# Altium schematic sheet eDP.SchDoc(eDP)
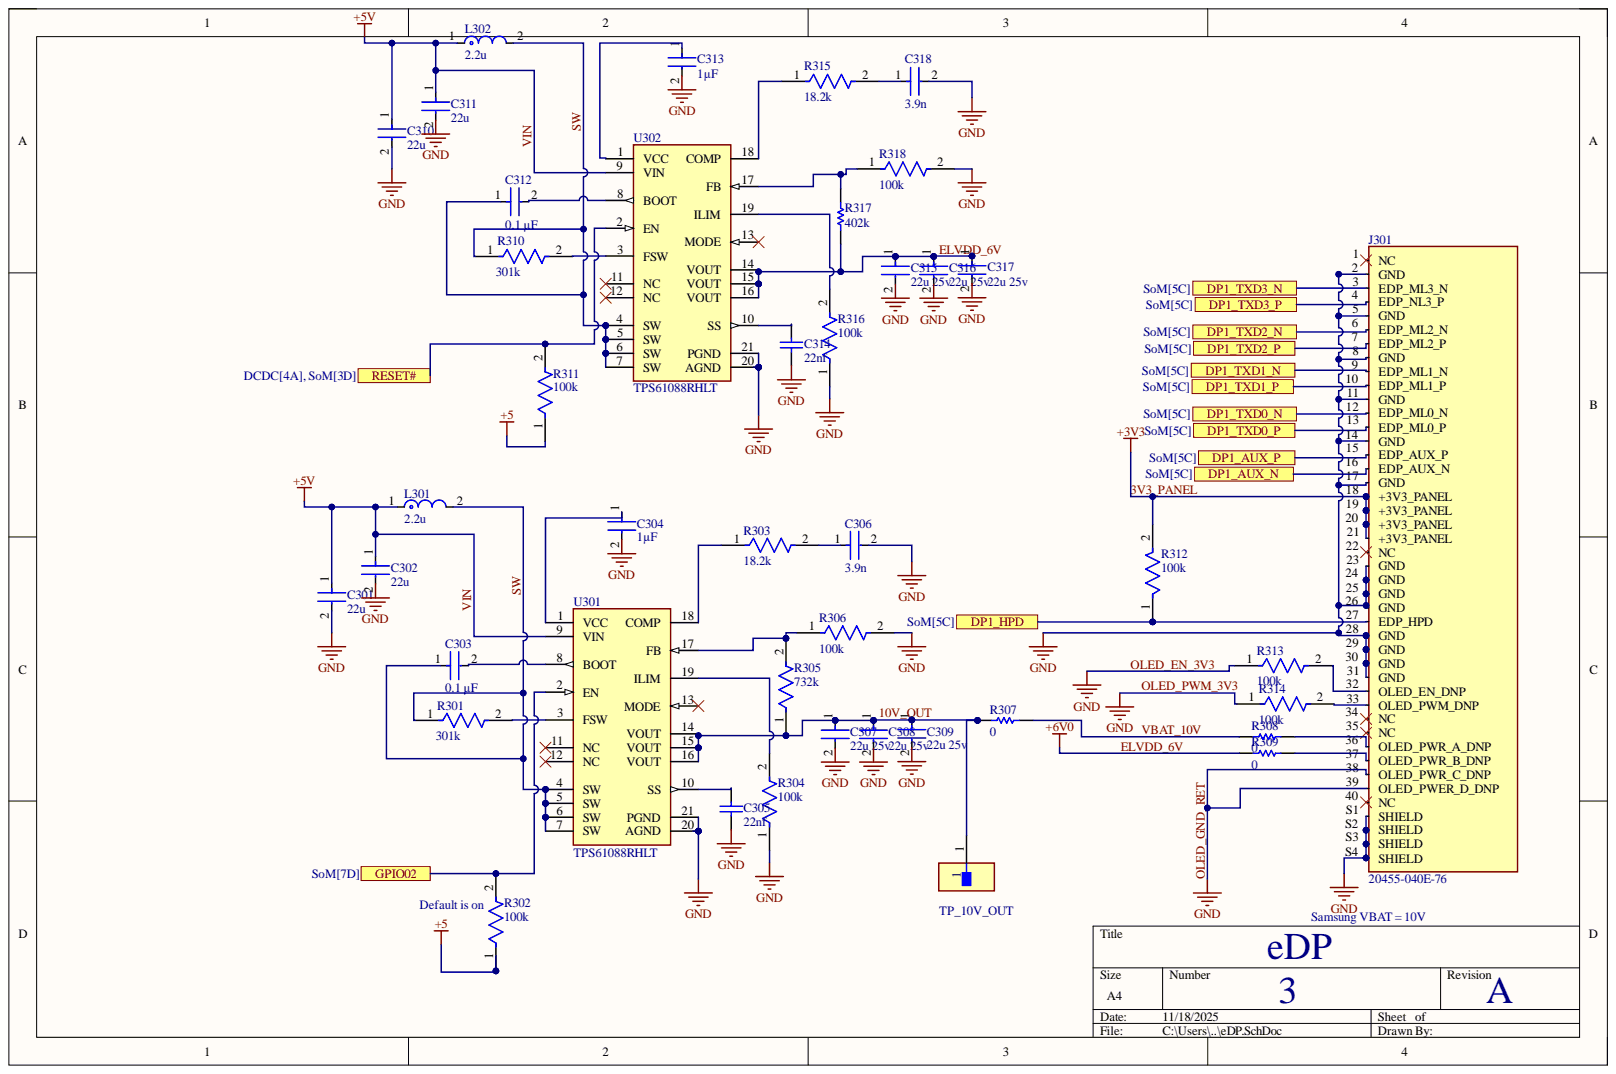
[diagram p0: eDP.SchDoc]

## Parts
C301  22u  [FP-CL10-IPC_B]
C302  22u  [FP-CL10-IPC_B]
C303  0.1 µF  [FP-GRM155-0_05-IPC_B]
C304  1µF  [FP-GRM15-0_1-MFG]
C305  22nf  [CAPC1608X90X35ML10T15]
C306  3.9n  [FP-GRM188-0_1-e0_2_0_5-IPC_B]
C307  22u 25v  [FP-GRM21B-0_2-e0_2_0_7-IPC_B]
C308  22u 25v  [FP-GRM21B-0_2-e0_2_0_7-IPC_B]
C309  22u 25v  [FP-GRM21B-0_2-e0_2_0_7-IPC_B]
C310  22u  [FP-CL10-IPC_B]
C311  22u  [FP-CL10-IPC_B]
C312  0.1 µF  [FP-GRM155-0_05-IPC_B]
C313  1µF  [FP-GRM15-0_1-MFG]
C314  22nf  [CAPC1608X90X35ML10T15]
C315  22u 25v  [FP-GRM21B-0_2-e0_2_0_7-IPC_B]
C316  22u 25v  [FP-GRM21B-0_2-e0_2_0_7-IPC_B]
C317  22u 25v  [FP-GRM21B-0_2-e0_2_0_7-IPC_B]
C318  3.9n  [FP-GRM188-0_1-e0_2_0_5-IPC_B]
J300  TP_10V_OUT  [FP-S2751-46R-MFG]
J301  20455-040E-76  [IPEX_20455-040E-76]
L301  2.2u  [FP-XAL5030-222MEC-MFG]
L302  2.2u  [FP-XAL5030-222MEC-MFG]
R301  301k  [FP-CRCW0603-e3-IPC_C]
R302  100k  [FP-CRCW0603C-e3-MFG]
R303  18.2k  [FP-CRCW0603-e3-IPC_C]
R304  100k  [FP-CRCW0603C-e3-MFG]
R305  732k  [FP-CRCW0603-e3-IPC_B]
R306  100k  [FP-CRCW0603C-e3-MFG]
R307  0  [RESC1005X40X25NL05T10]
R308  0  [RESC1005X40X25NL05T10]
R309  0  [RESC1005X40X25NL05T10]
R310  301k  [FP-CRCW0603-e3-IPC_C]
R311  100k  [FP-CRCW0603C-e3-MFG]
R312  100k  [FP-RC0402-0_4-IPC_A]
R313  100k  [FP-CRCW0603C-e3-MFG]
R314  100k  [FP-CRCW0603C-e3-MFG]
R315  18.2k  [FP-CRCW0603-e3-IPC_C]
R316  100k  [FP-CRCW0603C-e3-MFG]
R317  402k  [RESC1609X50X30ML10T20]
R318  100k  [FP-CRCW0603C-e3-MFG]
U301  TPS61088RHLT  [FP-RHL0020A-MFG]
U302  TPS61088RHLT  [FP-RHL0020A-MFG]

## Ports
DP1_AUX_N, DP1_AUX_P, DP1_HPD, DP1_TXD0_N, DP1_TXD0_P, DP1_TXD1_N, DP1_TXD1_P, DP1_TXD2_N, DP1_TXD2_P, DP1_TXD3_N, DP1_TXD3_P, GPIO02, RESET#

## Nets
+5: R302.1 R311.1
3V3_PANEL: J301.18(+3V3_PANEL) J301.19(+3V3_PANEL) J301.20(+3V3_PANEL) J301.21(+3V3_PANEL) R312.2
10V_OUT: C307.1 C308.1 C309.1 J300.1 R305.1 R307.1 U301.14(VOUT) U301.15(VOUT) U301.16(VOUT)
DP1_AUX_N: J301.16(EDP_AUX_N) ; port DP1_AUX_N
DP1_AUX_P: J301.15(EDP_AUX_P) ; port DP1_AUX_P
DP1_HPD: J301.27(EDP_HPD) R312.1 ; port DP1_HPD
DP1_TXD0_N: J301.12(EDP_ML0_N) ; port DP1_TXD0_N
DP1_TXD0_P: J301.13(EDP_ML0_P) ; port DP1_TXD0_P
DP1_TXD1_N: J301.9(EDP_ML1_N) ; port DP1_TXD1_N
DP1_TXD1_P: J301.10(EDP_ML1_P) ; port DP1_TXD1_P
DP1_TXD2_N: J301.6(EDP_ML2_N) ; port DP1_TXD2_N
DP1_TXD2_P: J301.7(EDP_ML2_P) ; port DP1_TXD2_P
DP1_TXD3_N: J301.3(EDP_ML3_N) ; port DP1_TXD3_N
DP1_TXD3_P: J301.4(EDP_NL3_P) ; port DP1_TXD3_P
ELVDD_6V: C315.1 C316.1 C317.1 R309.1 R317.1 U302.14(VOUT) U302.15(VOUT) U302.16(VOUT)
GPIO02: R302.2 U301.2(EN) ; port GPIO02
OLED_EN_3V3: C301.2 C302.2 C304.2 C305.1 C306.2 C307.2 C308.2 C309.2 C310.2 C311.2 C313.2 C314.1 C315.2 C316.2 C317.2 C318.2 J301.2(GND) J301.5(GND) J301.8(GND) J301.11(GND) J301.14(GND) J301.17(GND) J301.23(GND) J301.24(GND) J301.25(GND) J301.26(GND) J301.28(GND) J301.29(GND) J301.30(GND) J301.31(GND) J301.38(OLED_PWR_C_DNP) J301.39(OLED_PWER_D_DNP) J301.S1(SHIELD) J301.S2(SHIELD) J301.S3(SHIELD) J301.S4(SHIELD) R304.1 R306.2 R313.1 R314.1 R316.1 R318.2 U301.20(AGND) U301.21(PGND) U302.20(AGND) U302.21(PGND)
RESET#: R311.2 U302.2(EN) ; port RESET#
SW: C303.1 C312.1 L301.2 L302.2 R301.1 R310.1 U301.4(SW) U301.5(SW) U301.6(SW) U301.7(SW) U302.4(SW) U302.5(SW) U302.6(SW) U302.7(SW)
VBAT_10V: R307.2 R308.1
VIN: C301.1 C302.1 C310.1 C311.1 L301.1 L302.1 U301.9(VIN) U302.9(VIN)
NetC303_2: C303.2 U301.8(BOOT)
NetC304_1: C304.1 U301.1(VCC)
NetC305_2: C305.2 U301.10(SS)
NetC306_1: C306.1 R303.2
NetC312_2: C312.2 U302.8(BOOT)
NetC313_1: C313.1 U302.1(VCC)
NetC314_2: C314.2 U302.10(SS)
NetC318_1: C318.1 R315.2
NetJ301_32: J301.32(OLED_EN_DNP) R313.2
NetJ301_33: J301.33(OLED_PWM_DNP) R314.2
NetJ301_36: J301.36(OLED_PWR_A_DNP) R308.2
NetJ301_37: J301.37(OLED_PWR_B_DNP) R309.2
NetR301_2: R301.2 U301.3(FSW)
NetR303_1: R303.1 U301.18(COMP)
NetR304_2: R304.2 U301.19(ILIM)
NetR305_2: R305.2 R306.1 U301.17(FB)
NetR310_2: R310.2 U302.3(FSW)
NetR315_1: R315.1 U302.18(COMP)
NetR316_2: R316.2 U302.19(ILIM)
NetR317_2: R317.2 R318.1 U302.17(FB)

Not connected: J301 1(NC) 22(NC) 34(NC) 35(NC) 40(NC); U301 11(NC) 12(NC) 13(MODE); U302 11(NC) 12(NC) 13(MODE)

## Library data of the parts
C301 C302 C310 C311 = CMP-13271-002755-1: Cap Ceramic 22uF 16V X5R ±20% Pad SMD 0603 +85°C T/R; Capacitance: 22uF; Case Code (Imperial): 0603; Case Code (Metric): 1608; Case/Package: 0603; Component Kind: Standard; Library Name: Altium Content Vault; Max Operating Temperature: 85°C; Min Operating Temperature: -55°C; Mount: Surface Mount; Packaging: Tape and Reel; Tolerance: 20%; Voltage Rating: 16V
C303 C312 = CMP-06035-003750-1: Chip Multilayer Ceramic Capacitors for General Purpose, 0402, 0.10uF, X7R, 15%, 10%, 50V; Capacitance: 100nF; Case Code (Imperial): 0402; Case Code (Metric): 1005; Case/Package: 0402; Component Kind: Standard; Dielectric: X7R; Height: 0.5mm; Lead Free: Lead Free; Length: 0.039inch; Library Name: Altium Content Vault; Max Operating Temperature: 125°C; Min Operating Temperature: -55°C; Mount: Surface Mount; Package Quantity: 50000; Packaging: Tape and Reel; Resistor Type: Ceramic; Termination: SMD/SMT; Thickness: 0.022inch; Tolerance: 10%; Voltage Rating: 50V; Voltage Rating (DC): 50V; Weight: 0.000212oz; Width: 0.5mm
C304 C313 = CMP-2008-04556-2: CAP CER 1UF 10V X5R 0402; Manufacturer: Murata; Manufacturer Part Number: GRM155R61A105KE15D; Material: Ceramic; Temperature Coefficient: 15%; Tolerance: 10%; Automotive: No; Capacitance: 1uF; Category: Cap; Component Kind: Standard; ComponentLink2Description: Datasheet; ComponentLink2URL: https://search.murata.co.jp/Ceramy/image/img/A01X/G101/ENG/GRM155R61A105KE15-01A.pdf; Device Class L1: Passive Components; Device Class L2: Capacitors; Device Class L3: Ceramic Capacitors; Digikey Description: CAP CER 1UF 10V X5R 0402; Height: 0.55mm; Ipc Land Pattern Name: CAPC10050X50; Lead Free: Yes; Library Name: Altium Content Vault; Mouser Description: 0402 1 uF 10 V ±10% Tolerance X5R Surface Mount Multilayer Ceramic Capacitor; Octopart: https://octopart.com/grm155r61a105ke15d-murata-197442; Package: GRM15-0.1; RoHS: TRUE; Temperature: -55°C to +85°C; Temperature Characteristic: X5R; Temperature Range High: +85°C; Temperature Range Low: -55°C; Value: 1uF; Voltage Rating: 10V
C305 C314 = CMP-2006-02910-1: Manufacturer: Murata; Part Number: GRM188R71H223KA01D; Component Kind: Standard; Library Name: Altium Content Vault
C306 C318 = CMP-06035-027218-1: Chip Multilayer Ceramic Capacitors for General Purpose, 0603, 3900pF, C0G, 30ppm/°C, 5%, 50V; Capacitance: 3.9nF; Case Code (Imperial): 0603; Case Code (Metric): 1608; Case/Package: 0603; Component Kind: Standard; Dielectric: C0G; Height: 0.8mm; Length: 0.063inch; Library Name: Altium Content Vault; Max Operating Temperature: 125°C; Min Operating Temperature: -55°C; Mount: Surface Mount; Package Quantity: 10000; Packaging: Tape and Reel; Termination: SMD/SMT; Thickness: 0.035inch; Tolerance: 5%; Voltage: 50V; Voltage Rating: 50V; Voltage Rating (DC): 50V; Weight: 0.000222oz; Width: 0.8mm
C307-C309 C315-C317 = CMP-2007-03222-3: Chip Multilayer Ceramic Capacitors for General Purpose, 0805, 22uF, X5R, 15%, 20%, 25V; Capacitance: 22uF; Case Code (Imperial): 0805; Case Code (Metric): 2012; Case/Package: 0805; Component Kind: Standard; Depth: 1.25mm; Dielectric: X5R; Height: 1.25mm; Lead Free: Lead Free; Length: 2mm; Library Name: Altium Content Vault; Material: Ceramic; Max Operating Temperature: 85°C; Min Operating Temperature: -55°C; Mount: Surface Mount; Package Quantity: 3000; Packaging: Tape and Reel; REACH SVHC: No SVHC; Resistor Type: Ceramic; Termination: SMD/SMT; Thickness: 0.057inch; Tolerance: 20%; Voltage: 25V; Voltage Rating: 25V; Voltage Rating (DC): 25V; Weight: 0.000335oz; Width: 1.25mm
J300 = CMP-15817-000010-1: 10V nominal; Body Material: Brass; Plating: Tin; Color: Natural; Component Kind: Standard; Library Name: Altium Content Vault; Material: Copper Alloy; Mount: Surface Mount; Packaging: Cut Tape
J301 = CMP-002-00071-3: Conn Wire to Board RCP 40 POS 0.5mm Solder RA Side Entry SMDCABLINE® T/R; Component Kind: Standard; Contact Gender: Female; Pins: 40; Library Name: Evan
L301 L302 = CMP-11268-000158-1: Fixed Inductors 2.2uH Shld 20% 9.2A 14.5mOhms AECQ2 SRF: 38MHz Test Freq: 1MHz; Core Material: Composite; DC Resistance (DCR): 14.5mR; RMS Current (Irms): 9.7A; Shielding: Shielded; Test Frequency: 100kHz; Case/Package: SMD/SMT; Component Kind: Standard; Height: 3.1mm; Inductance: 2.2uH; Inductance Tolerance: 20%; Lead Free: Contains Lead; Length: 5.68mm; Library Name: Altium Content Vault; Max DC Current: 9.2A; Max Operating Temperature: 125°C; Min Operating Temperature: -40°C; Mount: Surface Mount; Package Quantity: 400; Packaging: Tape and Reel; RoHS Compliant: Yes; Saturation Current: 9.2A; Self Resonant Frequency: 38MHz; Series: XAL5030; Termination: SMD/SMT; Tolerance: 20%; Width: 5.48mm
R301 R310 = CMP-2000-03218-2: RES Thick Film, 301kΩ, 1%, 0.1W, 100ppm/°C, 0603; Max Power Dissipation: 100mW; Resistance: 301kR; Voltage Rating: 75V; Case Code (Imperial): 0603; Case Code (Metric): 1608; Case/Package: 0603; Component Kind: Standard; Composition: Thick Film; Contact Plating: Tin; Depth: 0.85mm; Features: Automotive AEC-Q200; Height: 0.45mm; Lead Free: Lead Free; Length: 1.55mm; Library Name: Altium Content Vault; Max Operating Temperature: 155°C; Military Standard: Not; Min Operating Temperature: -55°C; Mount: Surface Mount; Number of Pins: 2; Number of Terminations: 2; Package Quantity: 10; Packaging: Tape and Reel; Power Rating: 100mW; Radiation Hardening: No; Temperature Coefficient: 100ppm/°C; Termination: SMD/SMT; Tolerance: 1%; Voltage Rating (DC): 75V; Weight: 7.1E-05oz; Width: 0.033inch; Working Voltage: 75V
R302 R304 R306 R311 R313 R314 R316 R318 = CMP-02407-011574-1: RES SMD 100K OHM 1% 1/10W 0603; Power Rating: 100mW; Resistance: 100kR; Temperature Coefficient: 100ppm/°C; Case/Package: Molded; Component Kind: Standard; Contact Plating: Tin; Lead Free: Lead Free; Length: 1.55mm; Library Name: Altium Content Vault; Max Operating Temperature: 155°C; Min Operating Temperature: -55°C; Mount: Surface Mount; Resistor Type: Thick Film; RoHS Compliant: Yes; Termination: Wraparound; Tolerance: 1%
R303 R315 = CMP-2000-02961-2: RES Thick Film, 18.2kΩ, 1%, 0.1W, 100ppm/°C, 0603; Max Power Dissipation: 100mW; Resistance: 18.2kR; Voltage Rating: 75V; Case Code (Imperial): 0603; Case Code (Metric): 1608; Case/Package: 0603; Component Kind: Standard; Composition: Thick Film; Contact Plating: Tin; Depth: 0.85mm; Features: Automotive AEC-Q200; Height: 0.45mm; Lead Free: Lead Free; Length: 1.55mm; Library Name: Altium Content Vault; Max Operating Temperature: 155°C; Military Standard: Not; Min Operating Temperature: -55°C; Number of Pins: 2; Number of Terminations: 2; Package Quantity: 10; Packaging: Tape and Reel; Power Rating: 100mW; Radiation Hardening: No; Temperature Coefficient: 100ppm/°C; Termination: SMD/SMT; Tolerance: 1%; Voltage Rating (DC): 75V; Weight: 7.1E-05oz; Width: 0.85mm; Working Voltage: 75V
R305 = CMP-1012-00834-2: RES Thick Film, 732kΩ, 1%, 0.1W, 100ppm/°C, 0603; Max Power Dissipation: 100mW; Resistance: 732kR; Voltage Rating: 75V; Case Code (Imperial): 0603; Case Code (Metric): 1608; Case/Package: 0603; Component Kind: Standard; Composition: Thick Film; Contact Plating: Tin; Depth: 0.85mm; Features: Automotive AEC-Q200; Height: 0.02inch; Length: 1.55mm; Library Name: Altium Content Vault; Max Operating Temperature: 155°C; Military Standard: Not; Min Operating Temperature: -55°C; Number of Pins: 2; Number of Terminations: 2; Package Quantity: 10; Packaging: Tape and Reel; Power Rating: 100mW; Radiation Hardening: No; Temperature Coefficient: 100ppm/°C; Termination: SMD/SMT; Tolerance: 1%; Weight: 7.1E-05oz; Width: 0.033inch
R307-R309 = CMP-1011-00001-2: Manufacturer: Yageo / Phycomp; Part Number: RC0402JR-070RL; Component Kind: Standard; Library Name: Altium Content Vault
R312 = CMP-2002-07913-2: RES 100K OHM 5% 1/16W 0402; Max Power Dissipation: 63mW; Resistance: 100kR; Temperature Coefficient: 100ppm/°C; Voltage Rating: 50V; Case Code (Imperial): 0402; Case Code (Metric): 1005; Case/Package: 0402; Component Kind: Standard; Construction: Rectangular; Depth: 0.5mm; Features: Moisture Resistant; Height: 0.016inch; Lead Free: Lead Free; Length: 1mm; Library Name: Altium Content Vault; Max Operating Temperature: 155°C; Military Standard: Not; Min Operating Temperature: -55°C; Number of Terminations: 2; Package Quantity: 1; Packaging: Tape and Reel; Power Rating: 63mW; Resistance Tolerance: 5%; Resistor Type: Thick Film; RoHS Compliant: Yes; Series: RC; Size Code: 0402; Termination: SMD/SMT; Tolerance: 5%; Width: 0.02inch
R317 = CMP-2000-03334-1: Manufacturer: Vishay Dale; Part Number: CRCW0603402KFKEA; Component Kind: Standard; Library Name: Altium Content Vault
U301 U302 = CMP-04918-000117-1: IC REG BOOST ADJ 10A 20VQFN; Manufacturer: Texas Instruments; Max Duty Cycle: 90%; Max Junction Temp: +125°C; Max Supply Voltage: 12V; Min Supply Voltage: 2.7V; Nominal Supply Current: 1-110uA; Number Of Outputs: 1; Output Type: AdjustableProgrammable; Output Voltage: 4.5-12.6V; Switching Frequency: 200-2200kHz; Automotive: No; Category: IC; Component Kind: Standard; ComponentLink2Description: Datasheet; ComponentLink2URL: http://www.ti.com/lit/ds/symlink/tps61088.pdf; Device Class L1: Integrated Circuits (ICs); Device Class L2: Power Management ICs; Device Class L3: Voltage Regulators - Switching; Digikey Description: IC REG BOOST ADJ 10A 20VQFN; Height: 1mm; Lead Free: Yes; Library Name: Altium Content Vault; Manufacturer Part Number: TPS61088RHLT; Octopart: https://octopart.com/tps61088rhlt-texas+instruments-57155059; Output Current: 10A; Package: RHL0020A; RoHS: TRUE; Switching Topology: Boost; Temperature: -40°C to +85°C; Temperature Range High: +85°C; Temperature Range Low: -40°C
